# Altium schematic sheet backplane.SchDoc (continued)

## Library data of the parts
AUNO = CMP-1073962-71: Microcontroller board, Uno [Arduino] A000066 Microcontroller board, Uno 7640152110092; Number of Bits: 8; Component Kind: Standard; Library Name: CommunityVault; Octopart URL: http://octopart.com/a000066-arduino-21222240
CH-A1-CH-A9 CH-B1-CH-B9 CH-C1-CH-C9 CH-D1-CH-D9 CH-E1-CH-E9 CH-F1-CH-F9 CH-G1-CH-G9 CH-H1-CH-H9 CH-I1-CH-I9 CH-J1-CH-J9 = CMP-3894661-5: 2.54mm (.100") Pitch KK® Wire-to-Board Header, Vertical, with Friction Lock, 3 Circuits, Tin (Sn) Plating; Lead-Free Status: Lead Free; Number of Contacts: 4; Component Kind: Standard; Contact Plating: Tin; Housing Color: Natural; Library Name: CommunityVault; Material: Nylon; Number of Positions: 4; Orientation: Vertical; Pin Pitch: 2.54 mm; RoHS: Compliant; Size-Height: 11.7 mm; Size-Length: 10.2 mm
DISP1 = CMP-917f58758bad4eb8-4: Display: LED; 7-segment; 14.22mm; red; 0.9-2.8mcd; cathode; 0.56"; Forward Voltage: 2.10 V; Lead-Free Status: Lead Free; Pin Pitch: 2.54 mm; Component Kind: Standard; Library Name: CommunityVault; Lifecycle Status: Active; Manufacturer Part Number: HDSP-5503; Mounting Style: Through Hole; OctopartID: 917f58758bad4eb8; Octopart URL: http://octopart.com/hdsp-5503-avago-16308; Packaging: Tube; REACH SVHC Compliance: No SVHC; Size-Height: 12.6 mm; Size-Length: 17.0 mm; Size-Width: 12.6 mm
GND OSA OSB OSC OSD OSE OSF OSG OSH OSI OSJ PWR_INPUT = CMP-9ab5517917744572-3: Conn Terminal Block 2 POS 5.08mm Screw ST Cable Mount 16A; Component Kind: Standard; Library Name: CommunityVault; Octopart URL: https://octopart.com/em250502v-cooper+bussmann-46573735; Packaging: Bulk
GND-A GND-B GND-C GND-D GND-E GND-F GND-G GND-H GND-I GND-J GND-MAIN HP-A HP-B HP-C HP-D HP-E HP-F HP-G HP-H HP-I HP-J HP-MAIN LP-A LP-B LP-C LP-D LP-E LP-F LP-G LP-H LP-I LP-J LP-MAIN = CMP-0157c85d593dde90-2: Brass Bright Tin Plate .219 inch High for .109 inch Board Width Turret Terminal; Lead-Free Status: Lead Free; Pin Pitch: 2.77 mm; Component Kind: Standard; Contact Material: Brass; Contact Plating: Tin; Insulation Material: Non-Insulated; Library Name: CommunityVault; Material: Brass; OctopartID: 0157c85d593dde90; Octopart URL: http://octopart.com/1502-2-keystone-32012; RoHS: Compliant; Size-Diameter: 3.17 mm; Size-Length: 2.77 mm
  GND-A GND-B GND-C GND-D GND-E GND-F GND-G GND-H GND-I GND-J HP-A HP-B HP-C HP-D HP-E HP-F HP-G HP-H HP-I HP-J LP-A LP-B LP-C LP-D LP-E LP-F LP-G LP-H LP-I LP-J: Manufacturer Part Number: 1502-2
RPI = CMP-e7ab2d993b99386c-20: Dev Brd, Rpi Model b, Bcm2835 Processor; Component Kind: Standard; Library Name: CommunityVault
S-A S-B S-C S-D S-E S-F S-G S-H S-I S-J = CMP-dgd04bf3j3drhl5uyyz7-15: CONN DIN RCPT 64POS PCB GOLD; Component Kind: Standard; Library Name: CommunityVault
SR = CMP-13951118-28: Shift Register Single 8 Bit Serial To Serial Parallel 16 Pin Plastic DIP Tube; Digital Logic Level: CMOS; Lead-Free Status: Lead Free; Number of Bits: 8; Output Current Drive: -1.00 mA; Supply Voltage (DC): 2.00 V to 6.00 V; Voltage Nodes: 2.00 V, 5.00 V, 6.00 V; Case/Package: PDIP, SOIC; Component Kind: Standard; Library Name: CommunityVault; Lifecycle Status: Active; Manufacturer Part Number: SN74HC595N; Mounting Style: Through Hole; Number of Pins: 16; OctopartID: 168fdf857ed2c948; Octopart URL: https://octopart.com/sn74hc595n-texas+instruments-19771; Operating Temperature: 85.0 °C (max); Packaging: Tube; REACH SVHC Compliance: No SVHC; RoHS: Compliant
TMP36-1-TMP36-3 = CMP-2418272-2: Low Voltage Temperature Sensor, 2.7 to 5.5 V Supply, Industrial, 3-pin TO92 (T-3), Tray; Lead-Free Status: Contains Lead; Supply Current: 5e-05 A; Supply Voltage (DC): 5.0 V; Case/Package: TO-92; Component Kind: Standard; Library Name: CommunityVault; Lifecycle Status: Active; Manufacturer Part Number: TMP36GT9; Mounting Style: Through Hole; Number of Pins: 3; OctopartID: ea38233bdfbb35ff; Octopart Url: https://octopart.com/tmp36gt9-analog+devices-594149; RoHS: Non-Compliant
